# Altium schematic sheet 多功能主控.SchDoc
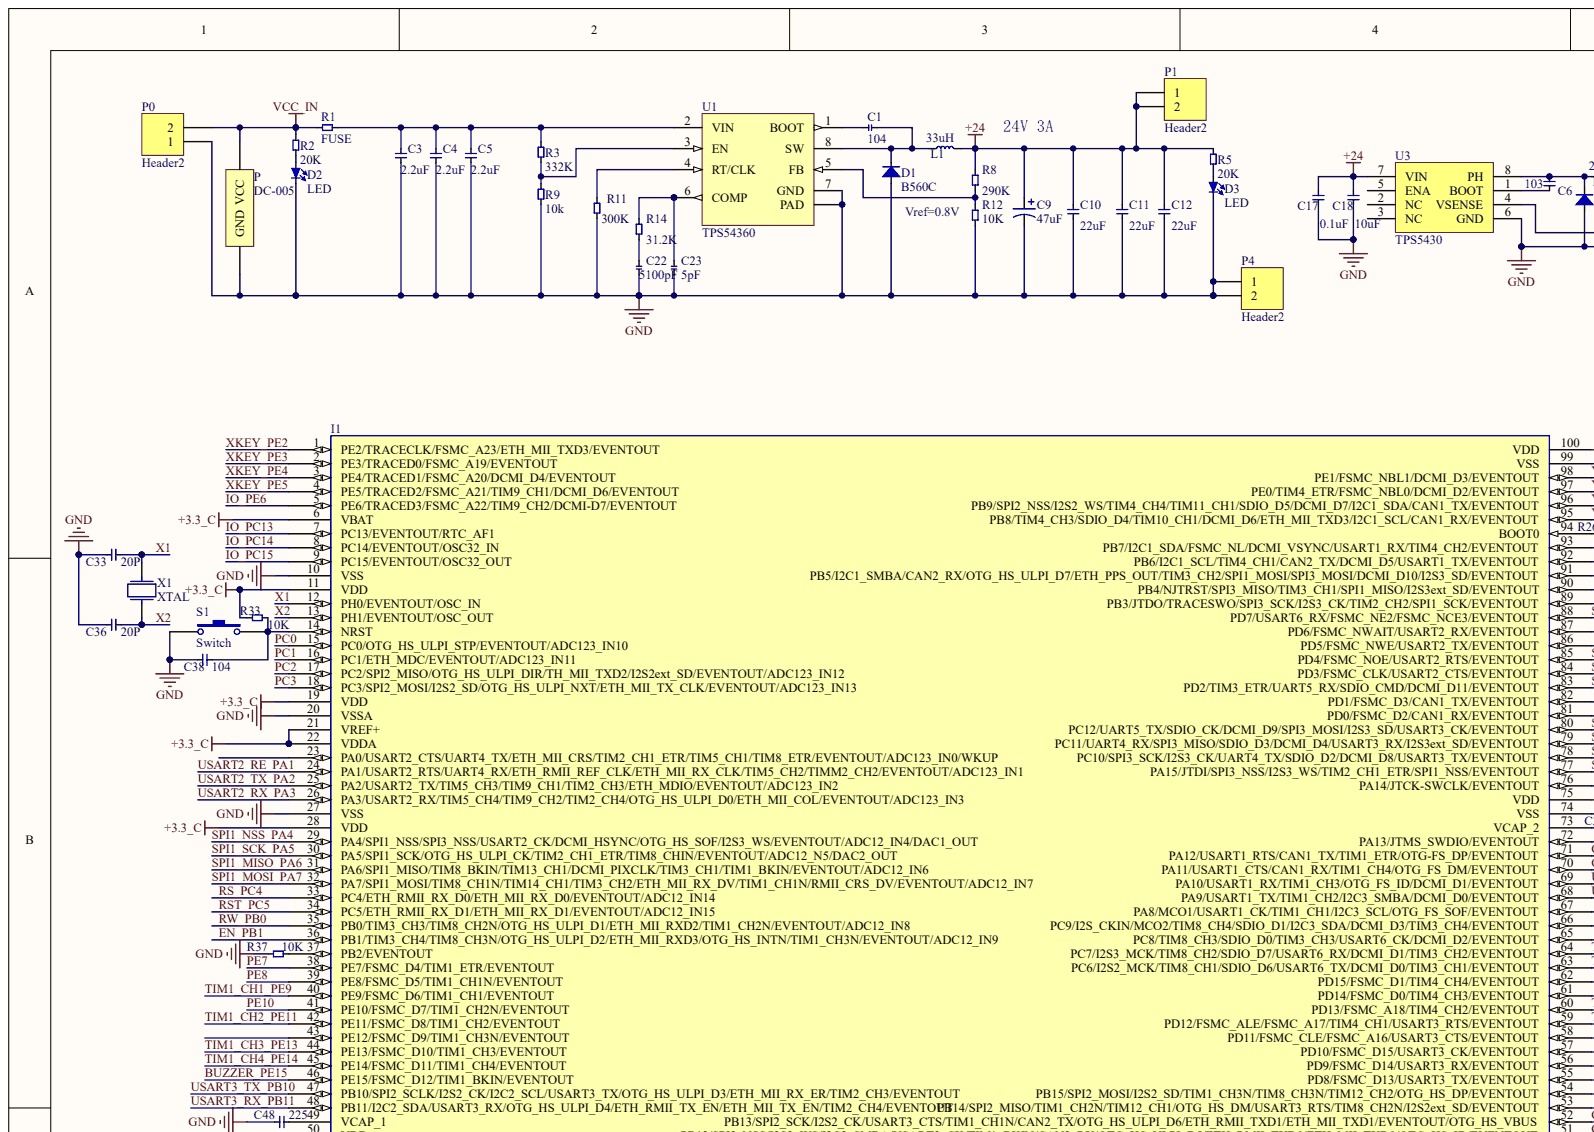
[diagram p0: 多功能主控.SchDoc, top left]
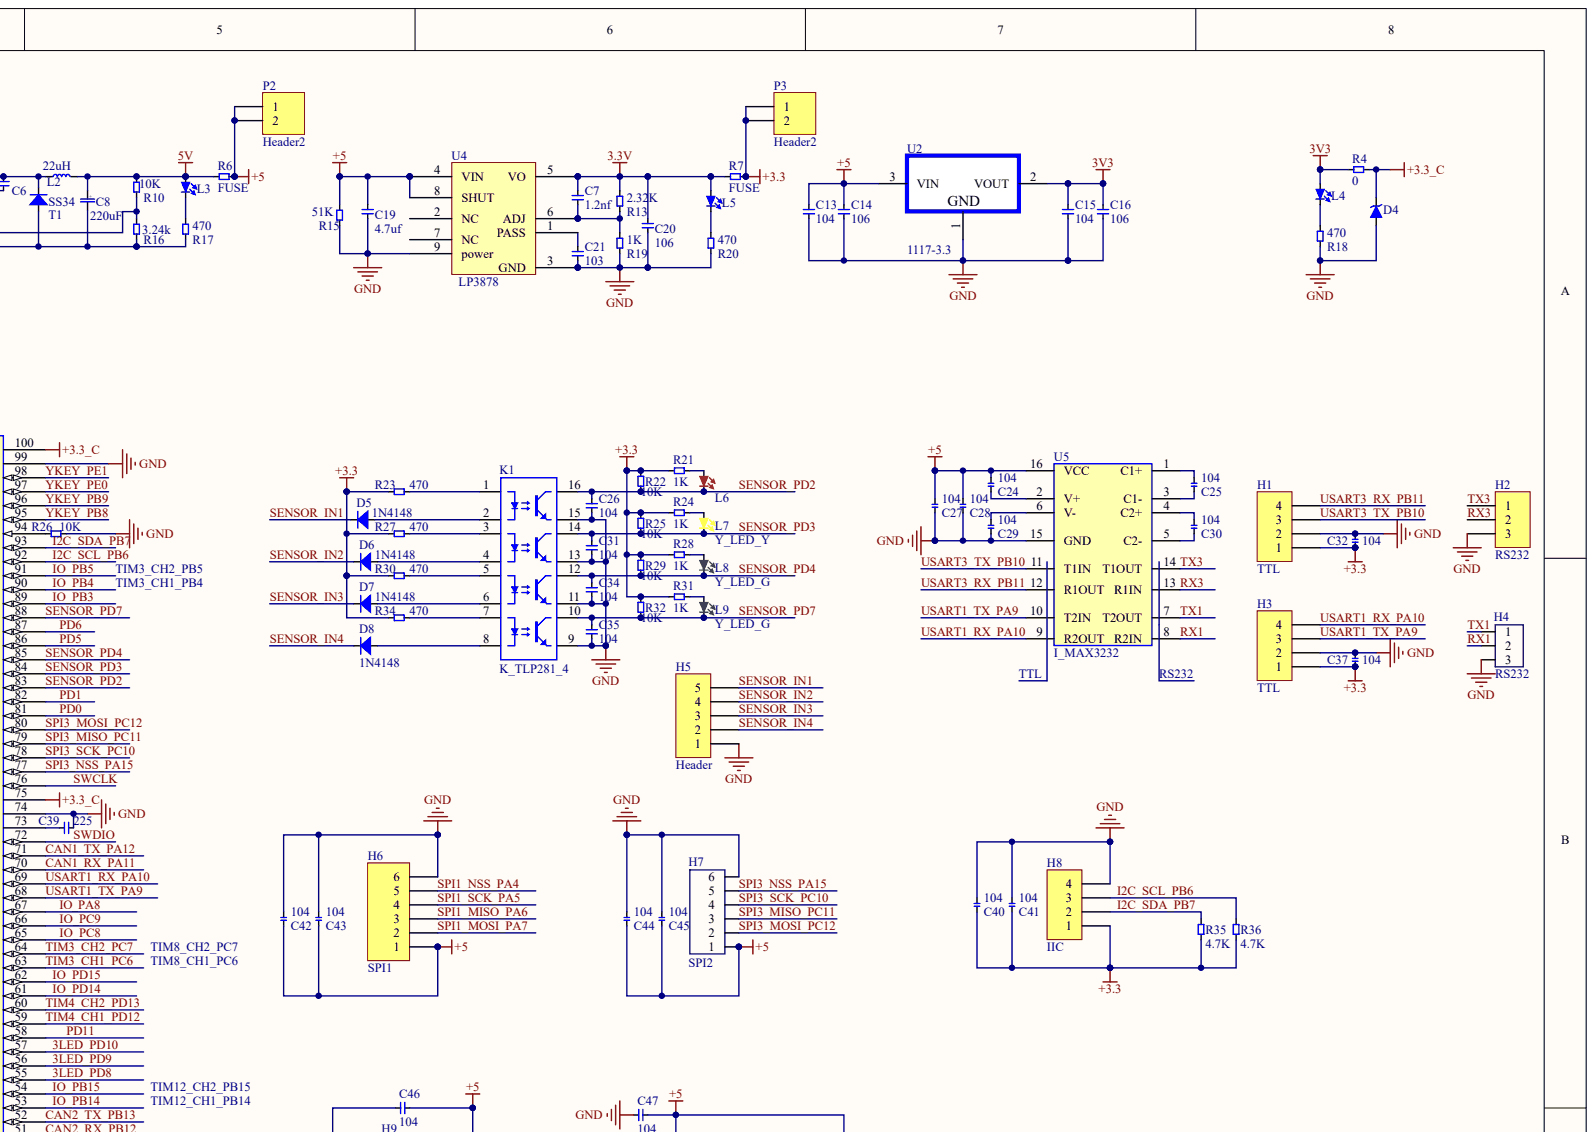
[diagram p1: 多功能主控.SchDoc, top right]
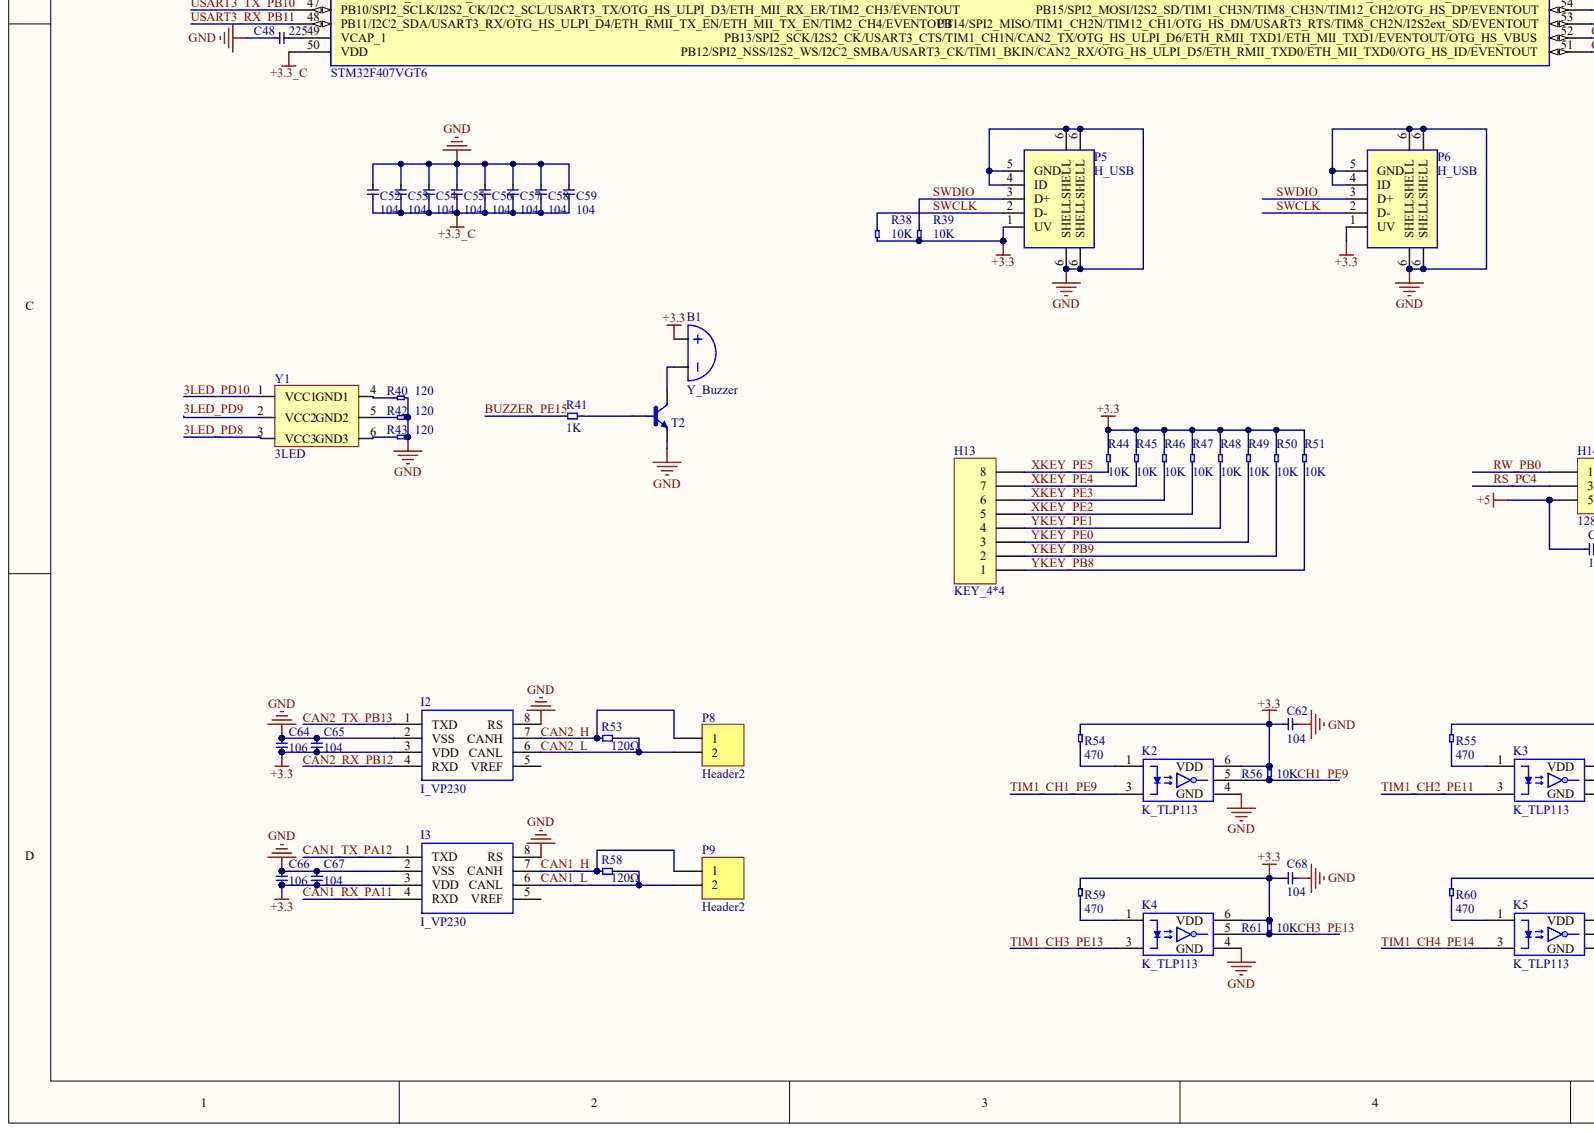
[diagram p2: 多功能主控.SchDoc, bottom left]
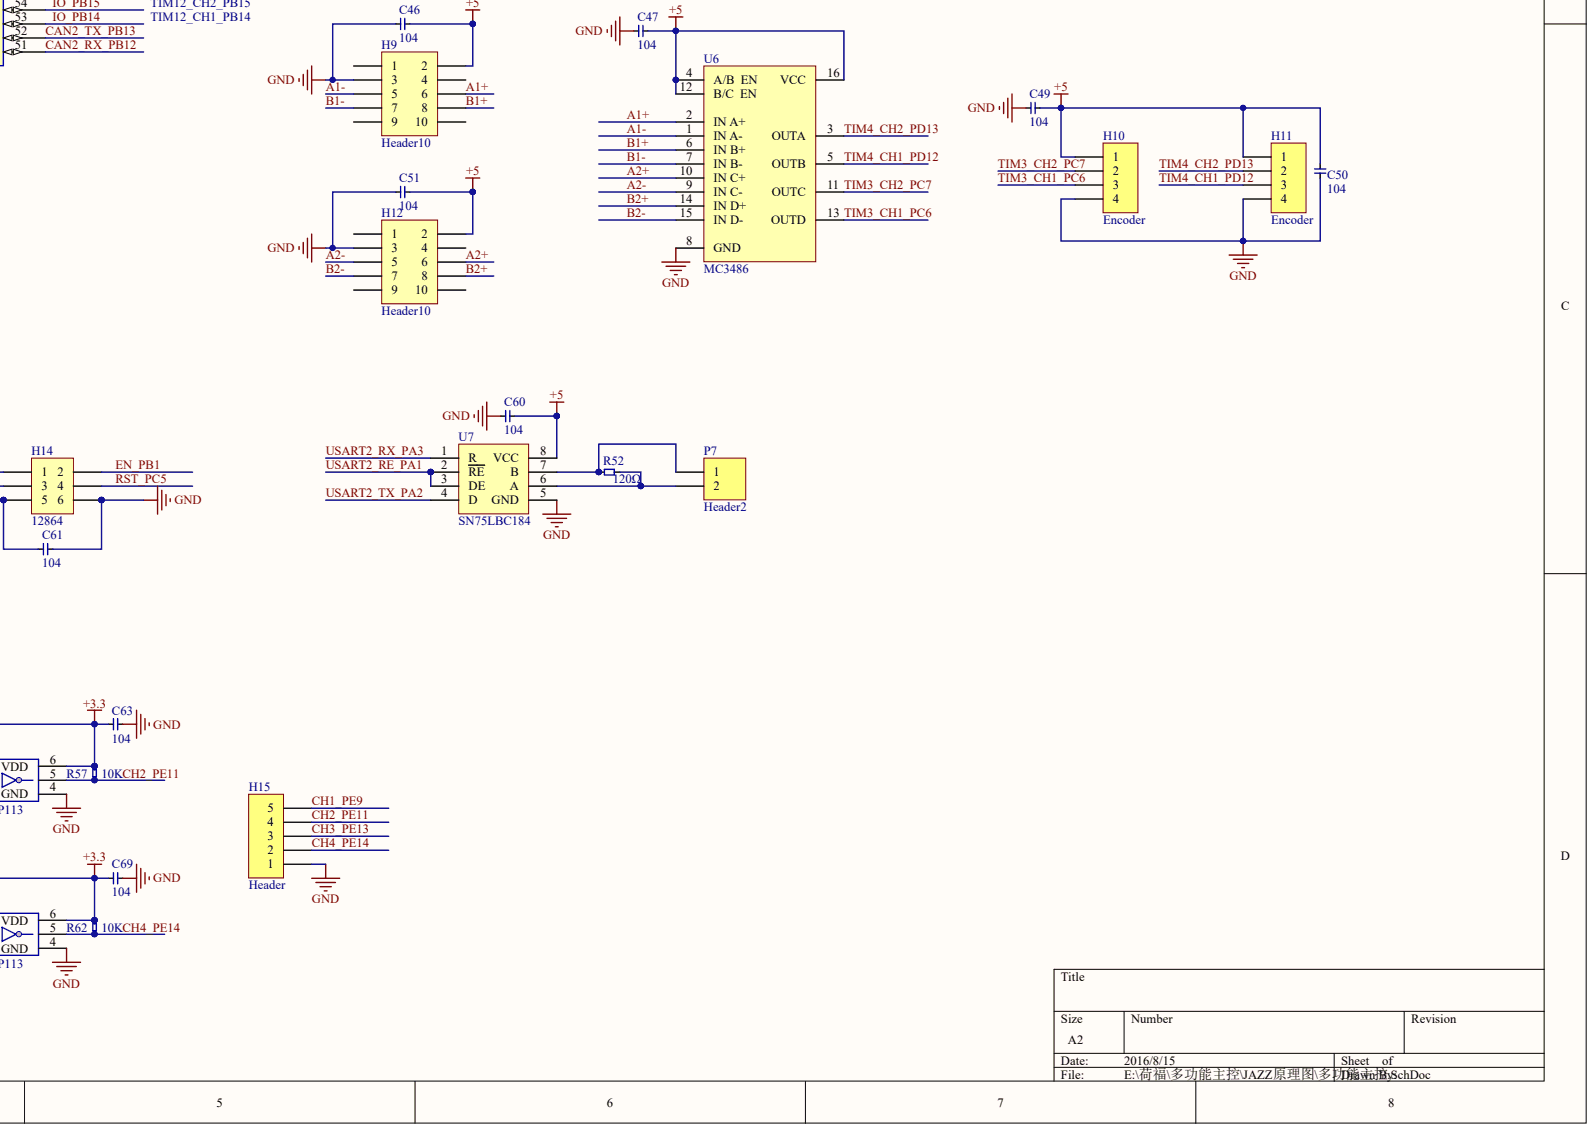
[diagram p3: 多功能主控.SchDoc, bottom right]

## Parts
B1  Y_Buzzer
C1  104
C3  2.2uF
C4  2.2uF
C5  2.2uF
C6  103
C7  1.2nf
C8  220uF
C9  47uF
C10  22uF
C11  22uF
C12  22uF
C13  104
C14  106
C15  104
C16  106
C17  0.1uF
C18  10uF
C19  4.7uf
C20  106
C21  103
C22  5100pF
C23  5pF
C24  104
C25  104
C26  104
C27  104
C28  104
C29  104
C30  104
C31  104
C32  104
C33  20P
C34  104
C35  104
C36  20P
C37  104
C38  104
C39  225
C40  104
C41  104
C42  104
C43  104
C44  104
C45  104
C46  104
C47  104
C48  225
C49  104
C50  104
C51  104
C52  104
C53  104
C54  104
C55  104
C56  104
C57  104
C58  104
C59  104
C60  104
C61  104
C62  104
C63  104
C64  106
C65  104
C66  106
C67  104
C68  104
C69  104
D1  B560C
D2  LED
D3  LED
D4  (no value)
D5  1N4148
D6  1N4148
D7  1N4148
D8  1N4148
H1  TTL
H2  RS232
H3  TTL
H4  RS232
H5  Header
H6  SPI1
H7  SPI2
H8  IIC
H9  Header10
H10  Encoder
H11  Encoder
H12  Header10
H13  KEY_4*4
H14  12864
H15  Header
I1  STM32F407VGT6
I2  I_VP230
I3  I_VP230
K1  K_TLP281_4
K2  K_TLP113
K3  K_TLP113
K4  K_TLP113
K5  K_TLP113
L1  33uH
L2  22uH
L3  Y_LED_B  (value not drawn)
L4  Y_LED_B  (value not drawn)
L5  Y_LED_B  (value not drawn)
L6  Y_LED_R  (value not drawn)
L7  Y_LED_Y
L8  Y_LED_G
L9  Y_LED_G
P  DC-005
P0  Header2
P1  Header2
P2  Header2
P3  Header2
P4  Header2
P5  H_USB
P6  H_USB
P7  Header2
P8  Header2
P9  Header2
R1  FUSE
R2  20K
R3  332K
R4  0
R5  20K
R6  FUSE
R7  FUSE
R8  290K
R9  10k
R10  10K
R11  300K
R12  10K
R13  2.32K
R14  31.2K
R15  51K
R16  3.24k
R17  470
R18  470
R19  1K
R20  470
R21  1K
R22  10K
R23  470
R24  1K
R25  10K
R26  10K
R27  470
R28  1K
R29  10K
R30  470
R31  1K
R32  10K
R33  10K
R34  470
R35  4.7K
R36  4.7K
R37  10K
R38  10K
R39  10K
R40  120
R41  1K
R42  120
R43  120
R44  10K
R45  10K
R46  10K
R47  10K
R48  10K
R49  10K
R50  10K
R51  10K
R52  120Ω
R53  120Ω
R54  470
R55  470
R56  10K
R57  10K
R58  120Ω
R59  470
R60  470
R61  10K
R62  10K
S1  Switch
T1  SS34
T2  8050  (value not drawn)
U1  TPS54360
U2  1117-3.3
U3  TPS5430
U4  LP3878
U5  I_MAX3232
U6  MC3486
U7  SN75LBC184
X1  XTAL
Y1  3LED

## Nets
3.3V: C7.2 C20.2 L5.1 R7.1 R13.2 U4.5(VO)
3V3: C15.2 C16.2 L4.1 R4.1 U2.2
5V: C8.1 L2.2 L3.1 R6.1 R10.2
+3.3: B1.1 C32.1 C37.1 C40.1 C41.1 C62.1 C63.1 C64.2 C65.2 C66.2 C67.2 C68.1 C69.1 H1.1 H3.1 H8.1 I2.3(VDD) I3.3(VDD) K2.6(VDD) K3.6(VDD) K4.6(VDD) K5.6(VDD) P3.1 P3.2 P5.1(UV) P6.1(UV) R7.2 R21.1 R22.2 R23.1 R24.1 R25.2 R27.1 R28.1 R29.2 R30.1 R31.1 R32.2 R34.1 R35.1 R36.1 R38.2 R39.2 R44.1 R45.1 R46.1 R47.1 R48.1 R49.1 R50.1 R51.1 R54.2 R55.2 R56.2 R57.2 R59.2 R60.2 R61.2 R62.2
+3.3_C: C52.1 C53.1 C54.1 C55.1 C56.1 C57.1 C58.1 C59.1 D4.2 I1.6(VBAT) I1.11(VDD) I1.19(VDD) I1.21(VREF+) I1.22(VDDA) I1.28(VDD) I1.50(VDD) I1.75(VDD) I1.100(VDD) R4.2 R33.2
+5: C13.2 C14.2 C19.2 C24.2 C27.2 C28.2 C42.1 C43.1 C44.1 C45.1 C46.2 C47.2 C49.1 C50.2 C51.2 C60.2 C61.1 H6.1 H7.1 H9.2 H10.1 H11.1 H12.2 H14.5 P2.1 P2.2 R6.2 R15.2 U2.3 U4.4(VIN) U4.8(SHUT) U5.16(VCC) U6.4(A/B) U6.12(B/C) U6.16(VCC) U7.8(VCC)
+24: C9.1 C10.2 C11.2 C12.2 C17.2 C18.2 L1.2 P1.1 P1.2 R5.2 R8.2 U3.7(VIN)
GND: C3.1 C4.1 C5.1 C8.2 C9.2 C10.1 C11.1 C12.1 C13.1 C14.1 C15.1 C16.1 C17.1 C18.1 C19.1 C20.1 C21.1 C22.1 C23.1 C26.1 C27.1 C28.1 C29.1 C31.1 C32.2 C33.2 C34.1 C35.1 C36.2 C37.2 C38.2 C39.2 C40.2 C41.2 C42.2 C43.2 C44.2 C45.2 C46.1 C47.1 C48.1 C49.2 C50.1 C51.1 C52.2 C53.2 C54.2 C55.2 C56.2 C57.2 C58.2 C59.2 C60.1 C61.2 C62.2 C63.2 C64.1 C65.1 C66.1 C67.1 C68.2 C69.2 D1.1 D2.2 D3.2 D4.1 H1.2 H2.3 H3.2 H4.3 H5.1 H6.6 H7.6 H8.4 H9.3 H10.4 H11.4 H12.3 H14.6 H15.1 I1.10(VSS) I1.20(VSSA) I1.27(VSS) I1.74(VSS) I1.99(VSS) I2.2(VSS) I2.8(RS) I3.2(VSS) I3.8(RS) K1.9 K1.11 K1.13 K1.15 K2.4(GND) K3.4(GND) K4.4(GND) K5.4(GND) P.2 P0.1 P4.1 P4.2 P5.4(ID) P5.5(GND) P5.6(SHELL_SHELL) P6.4(ID) P6.5(GND) P6.6(SHELL_SHELL) R9.1 R11.1 R12.1 R15.1 R16.1 R17.1 R18.1 R19.1 R20.1 R26.2 R37.1 R40.2 R42.2 R43.2 S1.1 T1.1 T2.2 U1.7(GND) U1.PAD U2.1 U3.6(GND) U4.3(GND) U4.9(power) U5.15(GND) U6.8(GND) U7.5(GND)
VCC_IN: P.1 P0.2 R1.1 R2.2
3LED_PD8: I1.55(PD8/FSMC_D13/USART3_TX/EVENTOUT) Y1.3(VCC3)
3LED_PD9: I1.56(PD9/FSMC_D14/USART3_RX/EVENTOUT) Y1.2(VCC2)
3LED_PD10: I1.57(PD10/FSMC_D15/USART3_CK/EVENTOUT) Y1.1(VCC1)
A1+: H9.6 U6.2(IN_A+)
A1-: H9.5 U6.1(IN_A-)
A2+: H12.6 U6.10(IN_C+)
A2-: H12.5 U6.9(IN_C-)
B1+: H9.8 U6.6(IN_B+)
B1-: H9.7 U6.7(IN_B-)
B2+: H12.8 U6.14(IN_D+)
B2-: H12.7 U6.15(IN_D-)
BUZZER_PE15: I1.46(PE15/FSMC_D12/TIM1_BKIN/EVENTOUT) R41.2
CAN1_H: I3.7(CANH) P9.1 R58.2
CAN1_L: I3.6(CANL) P9.2 R58.1
CAN1_RX_PA11: I1.70(PA11/USART1_CTS/CAN1_RX/TIM1_CH4/OTG_FS_DM/EVENTOUT) I3.4(RXD)
CAN1_TX_PA12: I1.71(PA12/USART1_RTS/CAN1_TX/TIM1_ETR/OTG-FS_DP/EVENTOUT) I3.1(TXD)
CAN2_H: I2.7(CANH) P8.1 R53.2
CAN2_L: I2.6(CANL) P8.2 R53.1
CAN2_RX_PB12: I1.51(PB12/SPI2_NSS/I2S2_WS/I2C2_SMBA/USART3_CK/TIM1_BKIN/CAN2_RX/OTG_HS_ULPI_D5/ETH_RMII_TXD0/ETH_MII_TXD0/OTG_HS_ID/EVENTOUT) I2.4(RXD)
CAN2_TX_PB13: I1.52(PB13/SPI2_SCK/I2S2_CK/USART3_CTS/TIM1_CH1N/CAN2_TX/OTG_HS_ULPI_D6/ETH_RMII_TXD1/ETH_MII_TXD1/EVENTOUT/OTG_HS_VBUS) I2.1(TXD)
CH1_PE9: H15.5 K2.5 R56.1
CH2_PE11: H15.4 K3.5 R57.1
CH3_PE13: H15.3 K4.5 R61.1
CH4_PE14: H15.2 K5.5 R62.1
EN_PB1: H14.2 I1.36(PB1/TIM3_CH4/TIM8_CH3N/OTG_HS_ULPI_D2/ETH_MII_RXD3/OTG_HS_INTN/TIM1_CH3N/EVENTOUT/ADC12_IN9)
I2C_SCL_PB6: H8.3 I1.92(PB6/I2C1_SCL/TIM4_CH1/CAN2_TX/DCMI_D5/USART1_TX/EVENTOUT) R36.2
I2C_SDA_PB7: H8.2 I1.93(PB7/I2C1_SDA/FSMC_NL/DCMI_VSYNC/USART1_RX/TIM4_CH2/EVENTOUT) R35.2
IO_PA8: I1.67(PA8/MCO1/USART1_CK/TIM1_CH1/I2C3_SCL/OTG_FS_SOF/EVENTOUT)
IO_PB3: I1.89(PB3/JTDO/TRACESWO/SPI3_SCK/I2S3_CK/TIM2_CH2/SPI1_SCK/EVENTOUT)
IO_PB4: I1.90(PB4/NJTRST/SPI3_MISO/TIM3_CH1/SPI1_MISO/I2S3ext_SD/EVENTOUT)
IO_PB5: I1.91(PB5/I2C1_SMBA/CAN2_RX/OTG_HS_ULPI_D7/ETH_PPS_OUT/TIM3_CH2/SPI1_MOSI/SPI3_MOSI/DCMI_D10/I2S3_SD/EVENTOUT)
IO_PB14: I1.53(PB14/SPI2_MISO/TIM1_CH2N/TIM12_CH1/OTG_HS_DM/USART3_RTS/TIM8_CH2N/I2S2ext_SD/EVENTOUT)
IO_PB15: I1.54(PB15/SPI2_MOSI/I2S2_SD/TIM1_CH3N/TIM8_CH3N/TIM12_CH2/OTG_HS_DP/EVENTOUT)
IO_PC8: I1.65(PC8/TIM8_CH3/SDIO_D0/TIM3_CH3/USART6_CK/DCMI_D2/EVENTOUT)
IO_PC9: I1.66(PC9/I2S_CKIN/MCO2/TIM8_CH4/SDIO_D1/I2C3_SDA/DCMI_D3/TIM3_CH4/EVENTOUT)
IO_PC13: I1.7(PC13/EVENTOUT/RTC_AF1)
IO_PC14: I1.8(PC14/EVENTOUT/OSC32_IN)
IO_PC15: I1.9(PC15/EVENTOUT/OSC32_OUT)
IO_PD14: I1.61(PD14/FSMC_D0/TIM4_CH3/EVENTOUT)
IO_PD15: I1.62(PD15/FSMC_D1/TIM4_CH4/EVENTOUT)
IO_PE6: I1.5(PE6/TRACED3/FSMC_A22/TIM9_CH2/DCMI-D7/EVENTOUT)
PC0: I1.15(PC0/OTG_HS_ULPI_STP/EVENTOUT/ADC123_IN10)
PC1: I1.16(PC1/ETH_MDC/EVENTOUT/ADC123_IN11)
PC2: I1.17(PC2/SPI2_MISO/OTG_HS_ULPI_DIR/TH_MII_TXD2/I2S2ext_SD/EVENTOUT/ADC123_IN12)
PC3: I1.18(PC3/SPI2_MOSI/I2S2_SD/OTG_HS_ULPI_NXT/ETH_MII_TX_CLK/EVENTOUT/ADC123_IN13)
PD0: I1.81(PD0/FSMC_D2/CAN1_RX/EVENTOUT)
PD1: I1.82(PD1/FSMC_D3/CAN1_TX/EVENTOUT)
PD5: I1.86(PD5/FSMC_NWE/USART2_TX/EVENTOUT)
PD6: I1.87(PD6/FSMC_NWAIT/USART2_RX/EVENTOUT)
PD11: I1.58(PD11/FSMC_CLE/FSMC_A16/USART3_CTS/EVENTOUT)
PE7: I1.38(PE7/FSMC_D4/TIM1_ETR/EVENTOUT)
PE8: I1.39(PE8/FSMC_D5/TIM1_CH1N/EVENTOUT)
PE10: I1.41(PE10/FSMC_D7/TIM1_CH2N/EVENTOUT)
RS_PC4: H14.3 I1.33(PC4/ETH_RMII_RX_D0/ETH_MII_RX_D0/EVENTOUT/ADC12_IN14)
RST_PC5: H14.4 I1.34(PC5/ETH_RMII_RX_D1/ETH_MII_RX_D1/EVENTOUT/ADC12_IN15)
RW_PB0: H14.1 I1.35(PB0/TIM3_CH3/TIM8_CH2N/OTG_HS_ULPI_D1/ETH_MII_RXD2/TIM1_CH2N/EVENTOUT/ADC12_IN8)
RX1: H4.2 U5.8(R2IN)
RX3: H2.2 U5.13(R1IN)
SENSOR_IN1: D5.2 H5.5
SENSOR_IN2: D6.2 H5.4
SENSOR_IN3: D7.2 H5.3
SENSOR_IN4: D8.2 H5.2
SENSOR_PD2: C26.2 I1.83(PD2/TIM3_ETR/UART5_RX/SDIO_CMD/DCMI_D11/EVENTOUT) K1.16 L6.2 R22.1
SENSOR_PD3: C31.2 I1.84(PD3/FSMC_CLK/USART2_CTS/EVENTOUT) K1.14 L7.2 R25.1
SENSOR_PD4: C34.2 I1.85(PD4/FSMC_NOE/USART2_RTS/EVENTOUT) K1.12 L8.2 R29.1
SENSOR_PD7: C35.2 I1.88(PD7/USART6_RX/FSMC_NE2/FSMC_NCE3/EVENTOUT) K1.10 L9.2 R32.1
SPI1_MISO_PA6: H6.3 I1.31(PA6/SPI1_MISO/TIM8_BKIN/TIM13_CH1/DCMI_PIXCLK/TIM3_CH1/TIM1_BKIN/EVENTOUT/ADC12_IN6)
SPI1_MOSI_PA7: H6.2 I1.32(PA7/SPI1_MOSI/TIM8_CH1N/TIM14_CH1/TIM3_CH2/ETH_MII_RX_DV/TIM1_CH1N/RMII_CRS_DV/EVENTOUT/ADC12_IN7)
SPI1_NSS_PA4: H6.5 I1.29(PA4/SPI1_NSS/SPI3_NSS/USART2_CK/DCMI_HSYNC/OTG_HS_SOF/I2S3_WS/EVENTOUT/ADC12_IN4/DAC1_OUT)
SPI1_SCK_PA5: H6.4 I1.30(PA5/SPI1_SCK/OTG_HS_ULPI_CK/TIM2_CH1_ETR/TIM8_CHIN/EVENTOUT/ADC12_N5/DAC2_OUT)
SPI3_MISO_PC11: H7.3 I1.79(PC11/UART4_RX/SPI3_MISO/SDIO_D3/DCMI_D4/USART3_RX/I2S3ext_SD/EVENTOUT)
SPI3_MOSI_PC12: H7.2 I1.80(PC12/UART5_TX/SDIO_CK/DCMI_D9/SPI3_MOSI/I2S3_SD/USART3_CK/EVENTOUT)
SPI3_NSS_PA15: H7.5 I1.77(PA15/JTDI/SPI3_NSS/I2S3_WS/TIM2_CH1_ETR/SPI1_NSS/EVENTOUT)
SPI3_SCK_PC10: H7.4 I1.78(PC10/SPI3_SCK/I2S3_CK/UART4_TX/SDIO_D2/DCMI_D8/USART3_TX/EVENTOUT)
SWCLK: I1.76(PA14/JTCK-SWCLK/EVENTOUT) P5.2(D-) P6.2(D-) R38.1
SWDIO: I1.72(PA13/JTMS_SWDIO/EVENTOUT) P5.3(D+) P6.3(D+) R39.1
TIM1_CH1_PE9: I1.40(PE9/FSMC_D6/TIM1_CH1/EVENTOUT) K2.3
TIM1_CH2_PE11: I1.42(PE11/FSMC_D8/TIM1_CH2/EVENTOUT) K3.3
TIM1_CH3_PE13: I1.44(PE13/FSMC_D10/TIM1_CH3/EVENTOUT) K4.3
TIM1_CH4_PE14: I1.45(PE14/FSMC_D11/TIM1_CH4/EVENTOUT) K5.3
TIM3_CH1_PC6: H10.3 I1.63(PC6/I2S2_MCK/TIM8_CH1/SDIO_D6/USART6_TX/DCMI_D0/TIM3_CH1/EVENTOUT) U6.13(OUTD)
TIM3_CH2_PC7: H10.2 I1.64(PC7/I2S3_MCK/TIM8_CH2/SDIO_D7/USART6_RX/DCMI_D1/TIM3_CH2/EVENTOUT) U6.11(OUTC)
TIM4_CH1_PD12: H11.3 I1.59(PD12/FSMC_ALE/FSMC_A17/TIM4_CH1/USART3_RTS/EVENTOUT) U6.5(OUTB)
TIM4_CH2_PD13: H11.2 I1.60(PD13/FSMC_A18/TIM4_CH2/EVENTOUT) U6.3(OUTA)
TX1: H4.1 U5.7(T2OUT)
TX3: H2.1 U5.14(T1OUT)
USART1_RX_PA10: H3.4 I1.69(PA10/USART1_RX/TIM1_CH3/OTG_FS_ID/DCMI_D1/EVENTOUT) U5.9(R2OUT)
USART1_TX_PA9: H3.3 I1.68(PA9/USART1_TX/TIM1_CH2/I2C3_SMBA/DCMI_D0/EVENTOUT) U5.10(T2IN)
USART2_RE_PA1: I1.24(PA1/USART2_RTS/UART4_RX/ETH_RMII_REF_CLK/ETH_MII_RX_CLK/TIM5_CH2/TIMM2_CH2/EVENTOUT/ADC123_IN1) U7.2(RE) U7.3(DE)
USART2_RX_PA3: I1.26(PA3/USART2_RX/TIM5_CH4/TIM9_CH2/TIM2_CH4/OTG_HS_ULPI_D0/ETH_MII_COL/EVENTOUT/ADC123_IN3) U7.1(R)
USART2_TX_PA2: I1.25(PA2/USART2_TX/TIM5_CH3/TIM9_CH1/TIM2_CH3/ETH_MDIO/EVENTOUT/ADC123_IN2) U7.4(D)
USART3_RX_PB11: H1.4 I1.48(PB11/I2C2_SDA/USART3_RX/OTG_HS_ULPI_D4/ETH_RMII_TX_EN/ETH_MII_TX_EN/TIM2_CH4/EVENTOUT) U5.12(R1OUT)
USART3_TX_PB10: H1.3 I1.47(PB10/SPI2_SCLK/I2S2_CK/I2C2_SCL/USART3_TX/OTG_HS_ULPI_D3/ETH_MII_RX_ER/TIM2_CH3/EVENTOUT) U5.11(T1IN)
X1: C33.1 I1.12(PH0/EVENTOUT/OSC_IN) X1.2
X2: C36.1 I1.13(PH1/EVENTOUT/OSC_OUT) X1.1
XKEY_PE2: H13.5 I1.1(PE2/TRACECLK/FSMC_A23/ETH_MII_TXD3/EVENTOUT) R47.2
XKEY_PE3: H13.6 I1.2(PE3/TRACED0/FSMC_A19/EVENTOUT) R46.2
XKEY_PE4: H13.7 I1.3(PE4/TRACED1/FSMC_A20/DCMI_D4/EVENTOUT) R45.2
XKEY_PE5: H13.8 I1.4(PE5/TRACED2/FSMC_A21/TIM9_CH1/DCMI_D6/EVENTOUT) R44.2
YKEY_PB8: H13.1 I1.95(PB8/TIM4_CH3/SDIO_D4/TIM10_CH1/DCMI_D6/ETH_MII_TXD3/I2C1_SCL/CAN1_RX/EVENTOUT) R51.2
YKEY_PB9: H13.2 I1.96(PB9/SPI2_NSS/I2S2_WS/TIM4_CH4/TIM11_CH1/SDIO_D5/DCMI_D7/I2C1_SDA/CAN1_TX/EVENTOUT) R50.2
YKEY_PE0: H13.3 I1.97(PE0/TIM4_ETR/FSMC_NBL0/DCMI_D2/EVENTOUT) R49.2
YKEY_PE1: H13.4 I1.98(PE1/FSMC_NBL1/DCMI_D3/EVENTOUT) R48.2
NetB1_2: B1.2 T2.3
NetC1_1: C1.1 D1.2 L1.1 U1.8(SW)
NetC1_2: C1.2 U1.1(BOOT)
NetC3_2: C3.2 C4.2 C5.2 R1.2 R3.2 U1.2(VIN)
NetC6_1: C6.1 U3.1(BOOT)
NetC6_2: C6.2 L2.1 T1.2 U3.8(PH)
NetC7_1: C7.1 R13.1 R19.2 U4.6(ADJ)
NetC21_2: C21.2 U4.1(PASS)
NetC22_2: C22.2 R14.1
NetC23_2: C23.2 R14.2 U1.6(COMP)
NetC24_1: C24.1 U5.2(V+)
NetC25_1: C25.1 U5.3(C1-)
NetC25_2: C25.2 U5.1(C1+)
NetC29_2: C29.2 U5.6(V-)
NetC30_1: C30.1 U5.5(C2-)
NetC30_2: C30.2 U5.4(C2+)
NetC38_1: C38.1 I1.14(NRST) R33.1 S1.2
NetC39_1: C39.1 I1.73(VCAP_2)
NetC48_2: C48.2 I1.49(VCAP_1)
NetD2_1: D2.1 R2.1
NetD3_1: D3.1 R5.1
NetD5_1: D5.1 K1.2
NetD6_1: D6.1 K1.4
NetD7_1: D7.1 K1.6
NetD8_1: D8.1 K1.8
NetI1_37: I1.37(PB2/EVENTOUT) R37.2
NetI1_94: I1.94(BOOT0) R26.1
NetK1_1: K1.1 R23.2
NetK1_3: K1.3 R27.2
NetK1_5: K1.5 R30.2
NetK1_7: K1.7 R34.2
NetK2_1: K2.1 R54.1
NetK3_1: K3.1 R55.1
NetK4_1: K4.1 R59.1
NetK5_1: K5.1 R60.1
NetL3_2: L3.2 R17.2
NetL4_2: L4.2 R18.2
NetL5_2: L5.2 R20.2
NetL6_1: L6.1 R21.2
NetL7_1: L7.1 R24.2
NetL8_1: L8.1 R28.2
NetL9_1: L9.1 R31.2
NetP7_1: P7.1 R52.2 U7.7(B)
NetP7_2: P7.2 R52.1 U7.6(A)
NetR3_1: R3.1 R9.2 U1.3(EN)
NetR8_1: R8.1 R12.2 U1.5(FB)
NetR10_1: R10.1 R16.2 U3.4(VSENSE)
NetR11_2: R11.2 U1.4(RT/CLK)
NetR40_1: R40.1 Y1.4(GND1)
NetR41_1: R41.1 T2.1
NetR42_1: R42.1 Y1.5(GND2)
NetR43_1: R43.1 Y1.6(GND3)

Not connected: H9 1 4 9 10; H12 1 4 9 10; I1 23(PA0/USART2_CTS/UART4_TX/ETH_MII_CRS/TIM2_CH1_ETR/TIM5_CH1/TIM8_ETR/EVENTOUT/ADC123_IN0/WKUP) 43(PE12/FSMC_D9/TIM1_CH3N/EVENTOUT); I2 5(VREF); I3 5(VREF); U3 2(NC) 3(NC) 5(ENA); U4 2(NC) 7(NC)

## Library data of the parts
B1 C1 C3-C69 D1-D8 H1-H15 I1-I3 K1-K5 L1-L9 P P0-P9 R1-R62 S1 T1 T2 U1-U7 X1 Y1 = (no symbol name)
  C9: Published: 8-Jun-2000; LatestRevisionDate: 17-Jul-2002; LatestRevisionNote: Re-released for DXP Platform.; PackageReference: POLAR0.8
  H4 H7 H13 T1: LatestRevisionDate: 17-Jul-2002; LatestRevisionNote: Re-released for DXP Platform.
  C9 H4 H7 H13 T1: Publisher: Altium Limited
